# Altium schematic sheet 04-Switch_RJ45.SchDoc(04-Switch_RJ45)
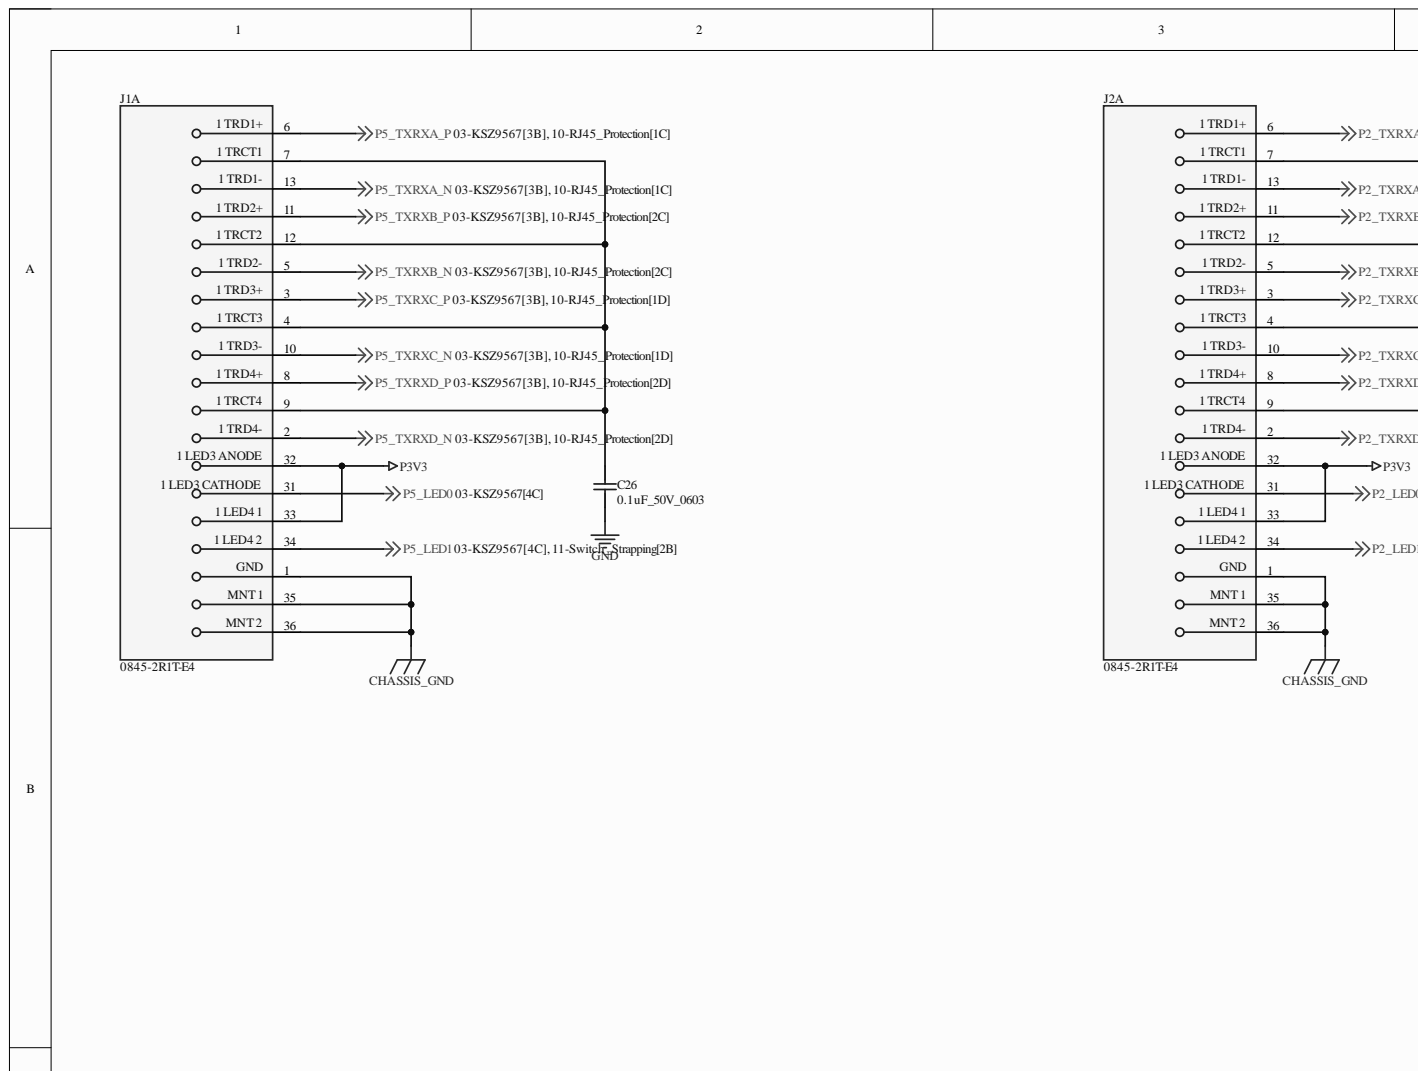
[diagram p0: 04-Switch_RJ45.SchDoc, top left]
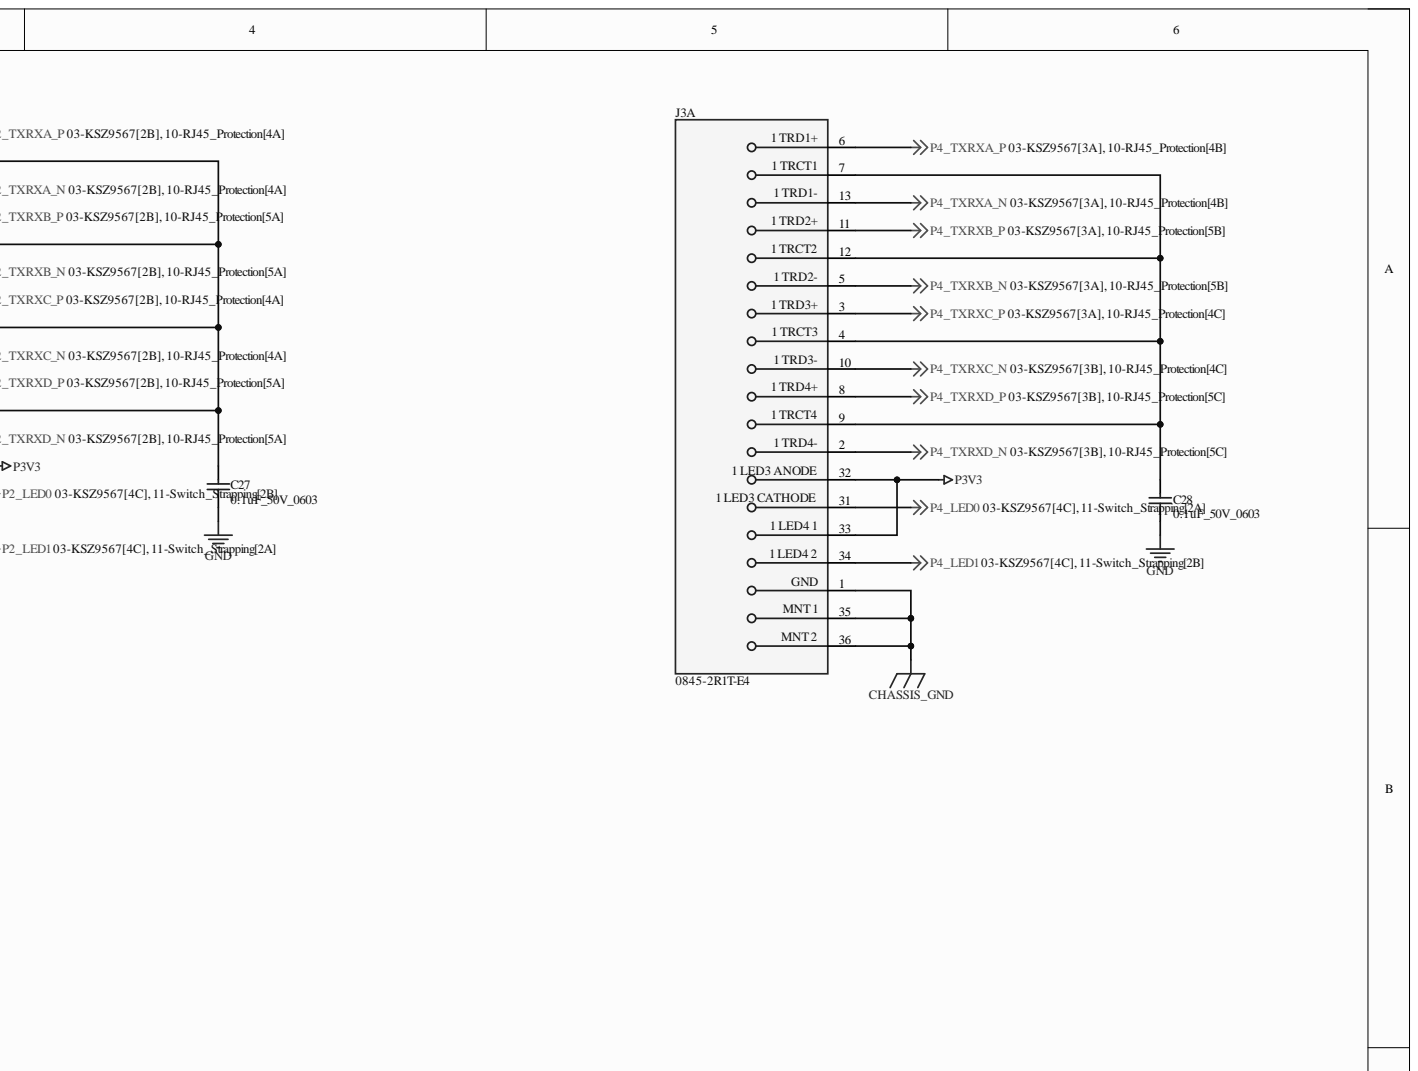
[diagram p1: 04-Switch_RJ45.SchDoc, top right]
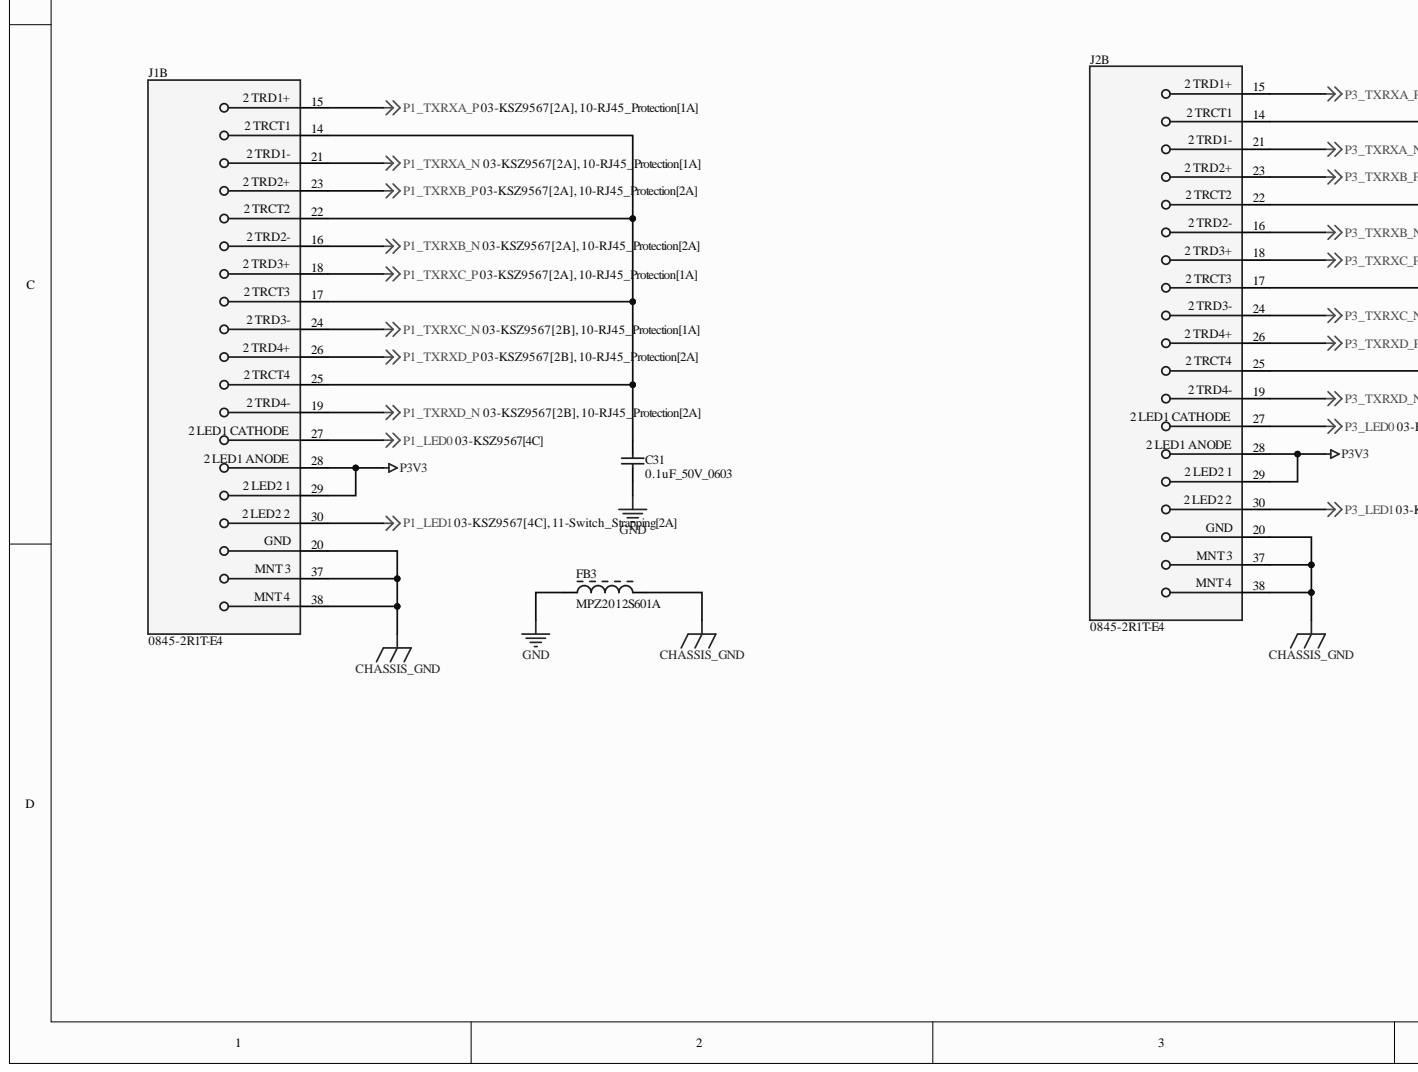
[diagram p2: 04-Switch_RJ45.SchDoc, bottom left]
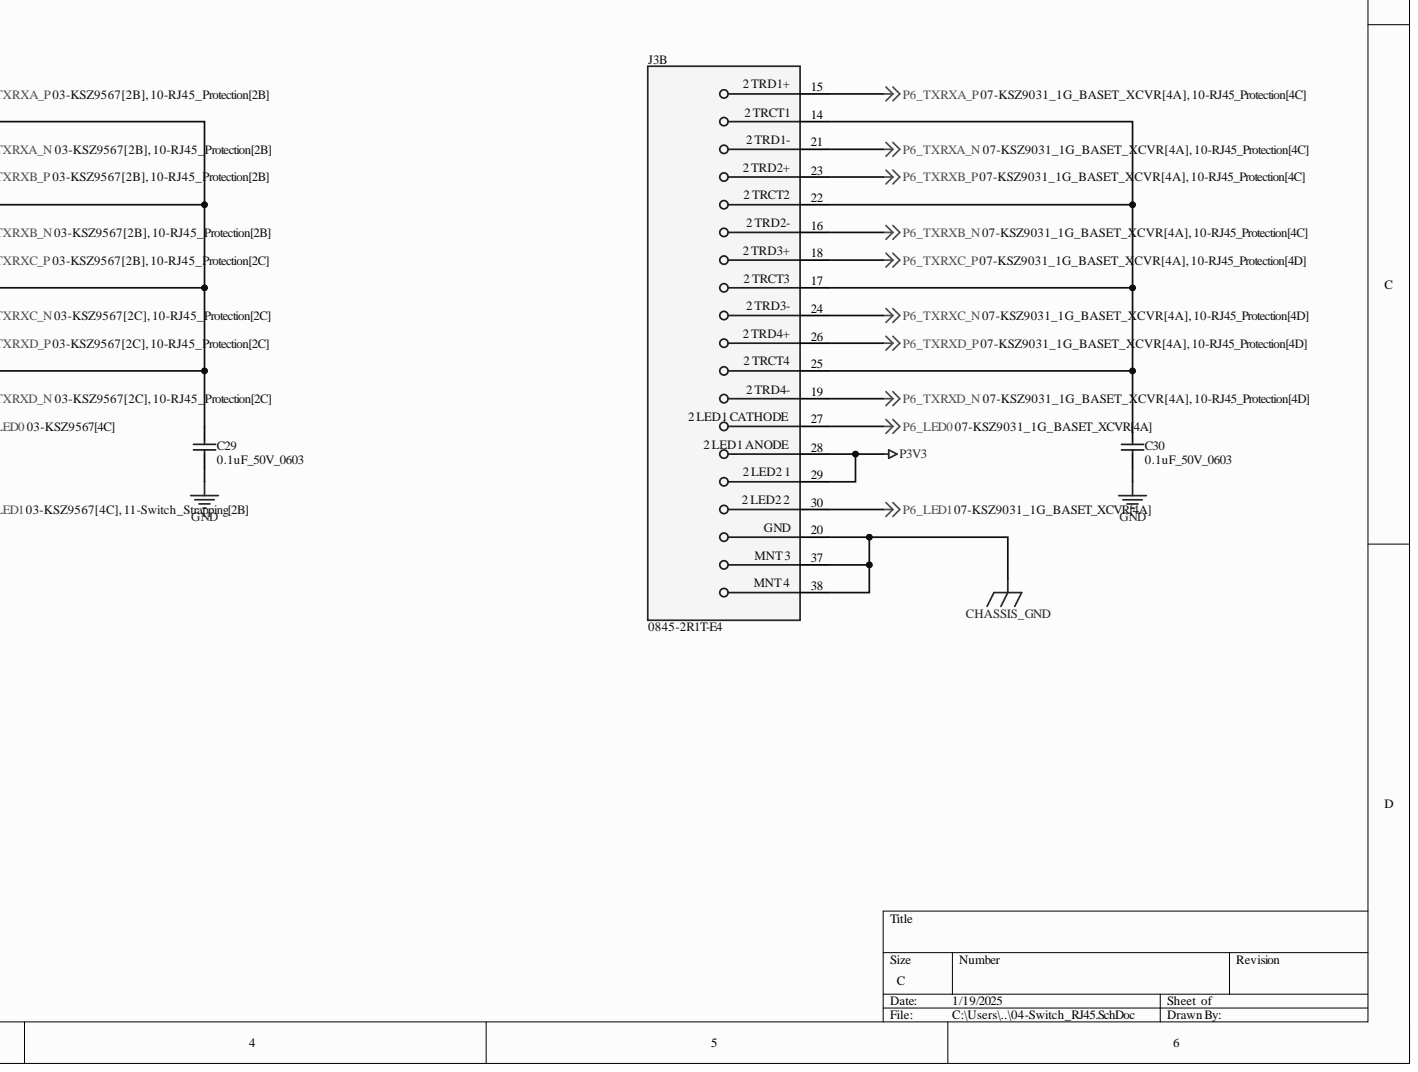
[diagram p3: 04-Switch_RJ45.SchDoc, bottom right]

## Parts
C26  0.1uF_50V_0603  [CAPC1608X90X35ML10T15]
C27  0.1uF_50V_0603  [CAPC1608X90X35ML10T15]
C28  0.1uF_50V_0603  [CAPC1608X90X35ML10T15]
C29  0.1uF_50V_0603  [CAPC1608X90X35ML10T15]
C30  0.1uF_50V_0603  [CAPC1608X90X35ML10T15]
C31  0.1uF_50V_0603  [CAPC1608X90X35ML10T15]
FB3  MPZ2012S601A  [TDK-MPZ2012_V]
J1  0845-2R1T-E4  [FP-0845-2R1T-E4-MFG]  (parts A,B)
J2  0845-2R1T-E4  [FP-0845-2R1T-E4-MFG]  (parts A,B)
J3  0845-2R1T-E4  [FP-0845-2R1T-E4-MFG]  (parts A,B)

## Nets
CHASSIS_GND: FB3.2 J1.1 J1.20 J1.35 J1.36 J1.37 J1.38 J2.1 J2.20 J2.35 J2.36 J2.37 J2.38 J3.1 J3.20 J3.35 J3.36 J3.37 J3.38
GND: C26.1 C27.1 C28.1 C29.1 C30.1 C31.1 FB3.1
P1_LED0: J1.27
P1_LED1: J1.30
P1_TXRXA_N: J1.21
P1_TXRXA_P: J1.15
P1_TXRXB_N: J1.16
P1_TXRXB_P: J1.23
P1_TXRXC_N: J1.24
P1_TXRXC_P: J1.18
P1_TXRXD_N: J1.19
P1_TXRXD_P: J1.26
P2_LED0: J2.31
P2_LED1: J2.34
P2_TXRXA_N: J2.13
P2_TXRXA_P: J2.6
P2_TXRXB_N: J2.5
P2_TXRXB_P: J2.11
P2_TXRXC_N: J2.10
P2_TXRXC_P: J2.3
P2_TXRXD_N: J2.2
P2_TXRXD_P: J2.8
P3_LED0: J2.27
P3_LED1: J2.30
P3_TXRXA_N: J2.21
P3_TXRXA_P: J2.15
P3_TXRXB_N: J2.16
P3_TXRXB_P: J2.23
P3_TXRXC_N: J2.24
P3_TXRXC_P: J2.18
P3_TXRXD_N: J2.19
P3_TXRXD_P: J2.26
P3V3: J1.28 J1.29 J1.32 J1.33 J2.28 J2.29 J2.32 J2.33 J3.28 J3.29 J3.32 J3.33
P4_LED0: J3.31
P4_LED1: J3.34
P4_TXRXA_N: J3.13
P4_TXRXA_P: J3.6
P4_TXRXB_N: J3.5
P4_TXRXB_P: J3.11
P4_TXRXC_N: J3.10
P4_TXRXC_P: J3.3
P4_TXRXD_N: J3.2
P4_TXRXD_P: J3.8
P5_LED0: J1.31
P5_LED1: J1.34
P5_TXRXA_N: J1.13
P5_TXRXA_P: J1.6
P5_TXRXB_N: J1.5
P5_TXRXB_P: J1.11
P5_TXRXC_N: J1.10
P5_TXRXC_P: J1.3
P5_TXRXD_N: J1.2
P5_TXRXD_P: J1.8
P6_LED0: J3.27
P6_LED1: J3.30
P6_TXRXA_N: J3.21
P6_TXRXA_P: J3.15
P6_TXRXB_N: J3.16
P6_TXRXB_P: J3.23
P6_TXRXC_N: J3.24
P6_TXRXC_P: J3.18
P6_TXRXD_N: J3.19
P6_TXRXD_P: J3.26
NetC26_2: C26.2 J1.4 J1.7 J1.9 J1.12
NetC27_2: C27.2 J2.4 J2.7 J2.9 J2.12
NetC28_2: C28.2 J3.4 J3.7 J3.9 J3.12
NetC29_2: C29.2 J2.14 J2.17 J2.22 J2.25
NetC30_2: C30.2 J3.14 J3.17 J3.22 J3.25
NetC31_2: C31.2 J1.14 J1.17 J1.22 J1.25

## Library data of the parts
C26-C31 = CMP-2100-03643-1: Chip Capacitor, 0.1 uF, +/- 10%, 50 V, -55 to 125 degC, 0603 (1608 Metric), RoHS, Tape and Reel; Manufacturer: Murata; Capacitance: 100nF; Dielectric: X7R; Dielectric Material: Ceramic; Insulation Resistance: 10GR; Voltage: 50V; Case Code (Imperial): 0603; Case Code (Metric): 1608; Case/Package: 0603; Component Kind: Standard; Contact Plating: Tin; Datasheet URL: http://psearch.en.murata.com/capacitor/product/GRM188R71H104KA93%23.pdf; Datasheet Version: 42675.0; Depth: 0.8mm; Height: 0.8mm; Lead Free: LeadFree; Length: 1.6mm; Library Name: Altium Content Vault; Manufacturer URL: http://www.murata.com/; Material: Ceramic; Max Operating Temperature: 125degC; Min Operating Temperature: -55degC; Mount: SurfaceMount; Number of Pins: 2; Package Description: 2-Pin Surface Mount Device, Body 1.6 x 0.8 mm; Package Quantity: 1; Package Reference: 0603; Packaging: TapeandReel; Radiation Hardening: No; REACH SVHC: NoSVHC; RoHSCompliant: true; Termination: SMD/SMT; Thickness: 0.035inch; Tolerance: 10%; Voltage Rating: 50V; Voltage Rating (DC): 50V; Width: 0.8mm
FB3 = CMP-2000-05400-1: Chip Bead for Power Line, 600 Ohm, +/- 25%, 2 A, -55 to 125 degC, 2-Pin SMD (0805), RoHS, Tape and Reel; Brand: TDK; Case Height: 0.85mm; Case Length: 2mm; Case Width: 1.25mm; Impedance: 600Ohms; Maximum DC Current: 2A; Maximum DC Resistance: 100mOhms; Test Frequency: 100MHz; Component Kind: Standard; Datasheet URL: https://datasheet.ciiva.com/23763/1750041-23763249.pdf; Datasheet Version: Jul-13; Dimensions: 1.25mmWx2mmLx0.85mmH; Factory Pack Quantity: 4000; Library Name: Altium Content Vault; Manufacturer: TDK; Manufacturer URL: http://www.tdk.com/; Operating Temperature Range: -55Cto+125C; Package / Case: 0805(2012metric); Package Description: 2-Pin Surface Mount Device, Body 2 x 1.25 mm; Package Reference: 805; Packaging: Reel; Product: FerriteChipBeads; Product Category: EMIFilterBeads,Chips&Arrays; RoHS: Details; Termination Style: SMD/SMT; Tolerance: 25%
J1-J3 = CMP-16034-000001-1: CONN MAGJACK 2PORT 1000 BASE-T; Manufacturer: Bel Fuse; Conn Gender: Female; Conn Orientation: Horizontal; Is Female: yes; Number Of Contacts: 26; Pitch: 2.01mm; Shielding: false; Automotive: No; Category: Conn; Component Kind: Standard; ComponentLink2Description: Datasheet; ComponentLink2URL: https://www.belfuse.com/resources/drawings/magneticsolutions/dr-mag-0845-2r1t-e4.pdf; Contact Material: Gold,Nickel; Device Class L1: Connectors; Device Class L2: Modular / Ethernet Connectors; Device Class L3: unset; Digikey Description: CONN MAGJACK 2PORT 1000 BASE-T; Height: 1.155inch; Lead Free: Yes; Library Name: Altium Content Vault; Manufacturer Part Number: 0845-2R1T-E4; Mouser Description: Conn RJ-45 F 16 POS 2.01mm Solder RA Thru-Hole 34 Terminal 2 Port Tray; Octopart: https://octopart.com/0845-2r1t-e4-bel+fuse-10528446; Package: 0; RoHS: TRUE; Temperature: 0°C to +70°C; Temperature Range High: +70°C; Temperature Range Low: 0°C
